# Altium schematic sheet BearPi_Release A0201.SchDoc
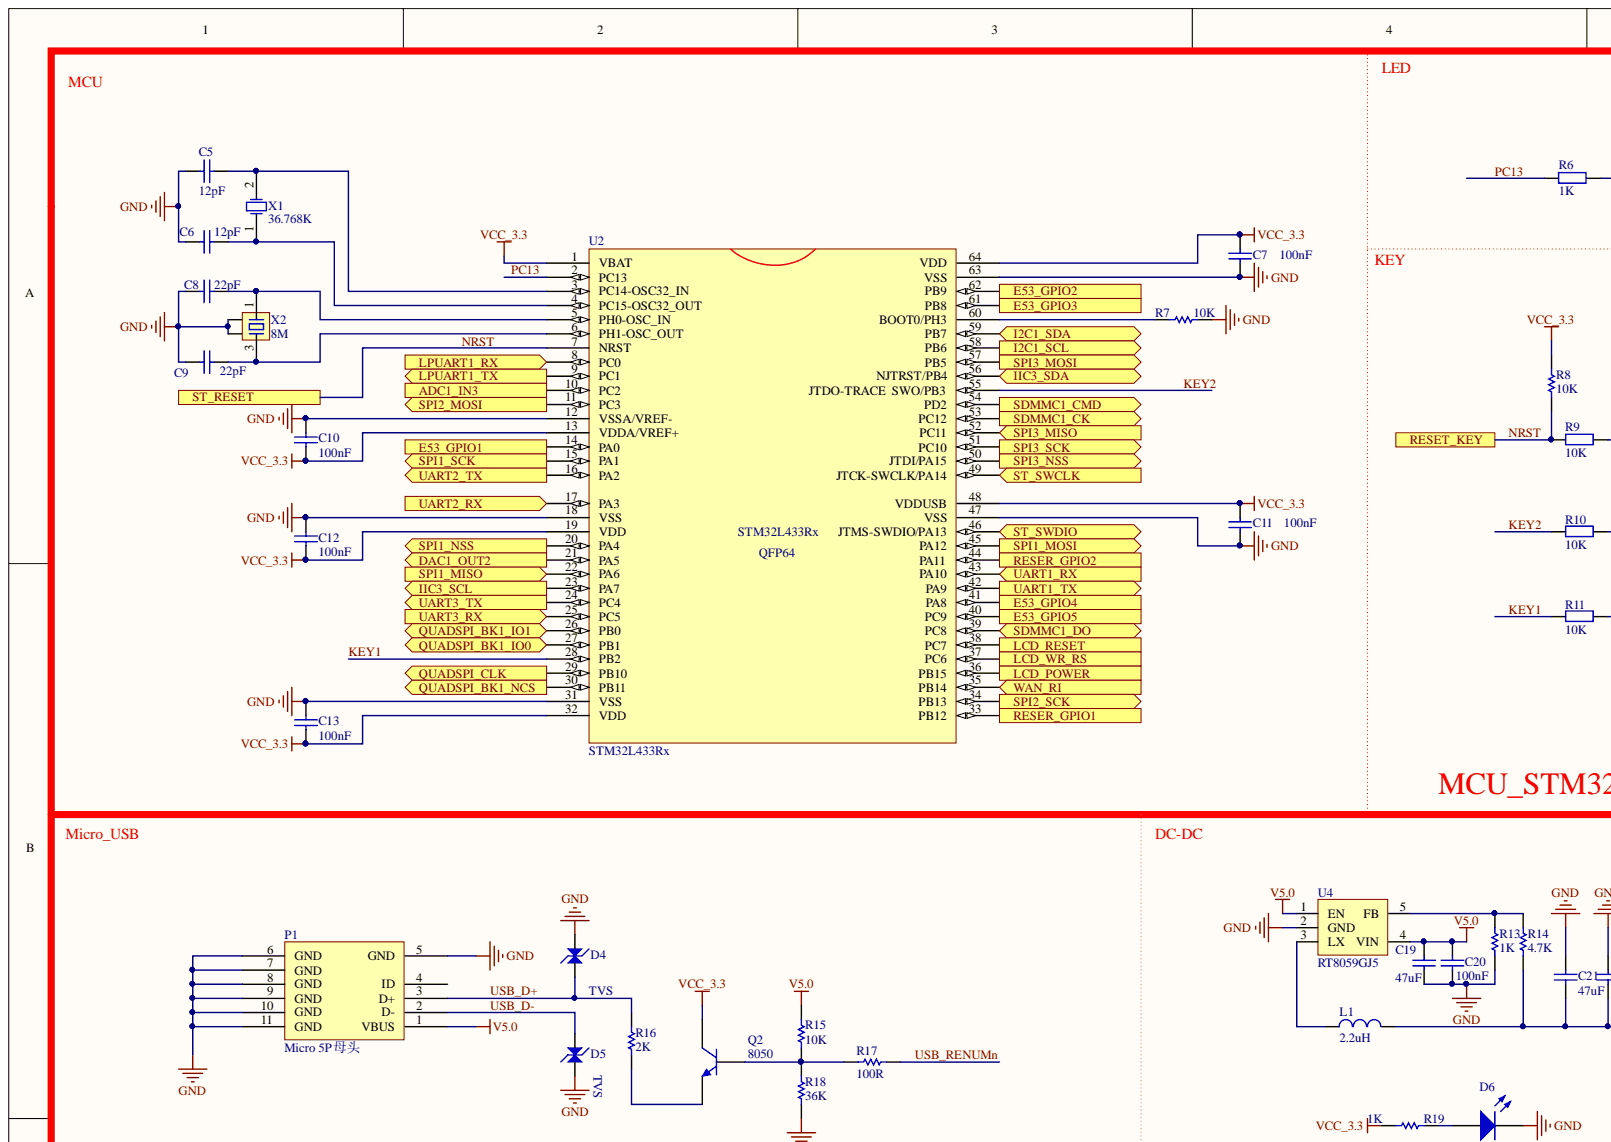
[diagram p0: BearPi_Release A0201.SchDoc, top left]
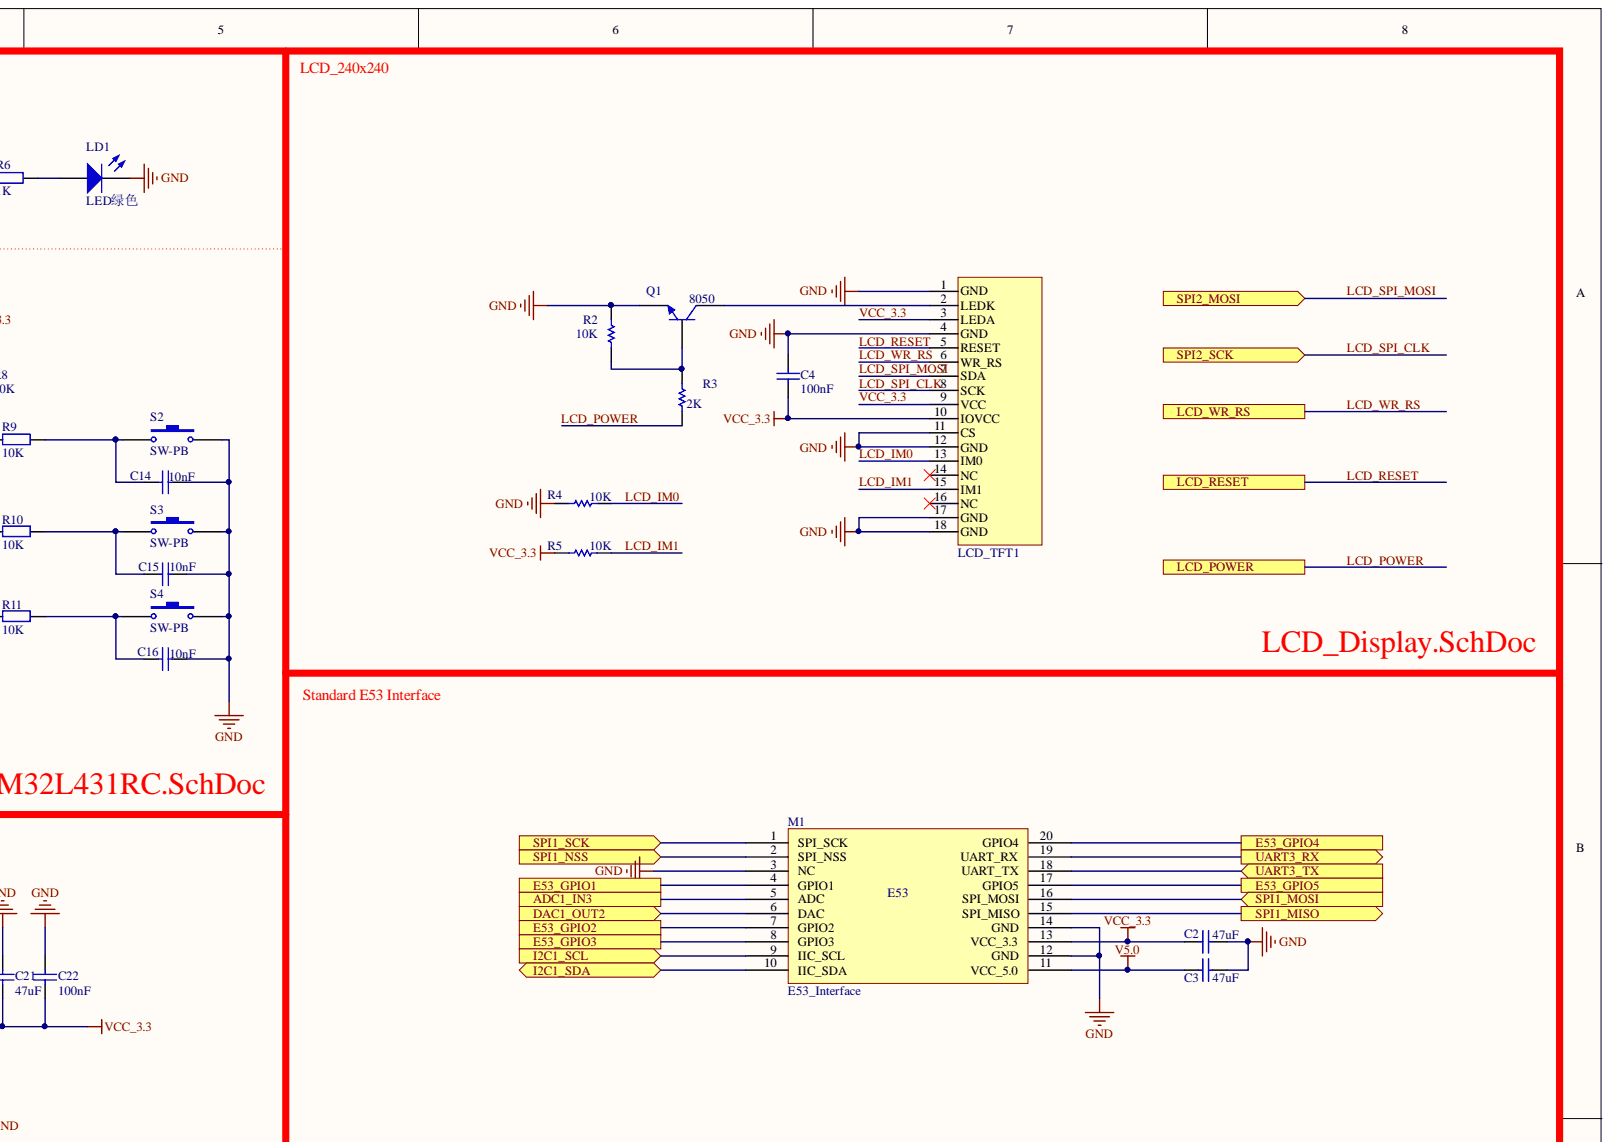
[diagram p1: BearPi_Release A0201.SchDoc, top right]
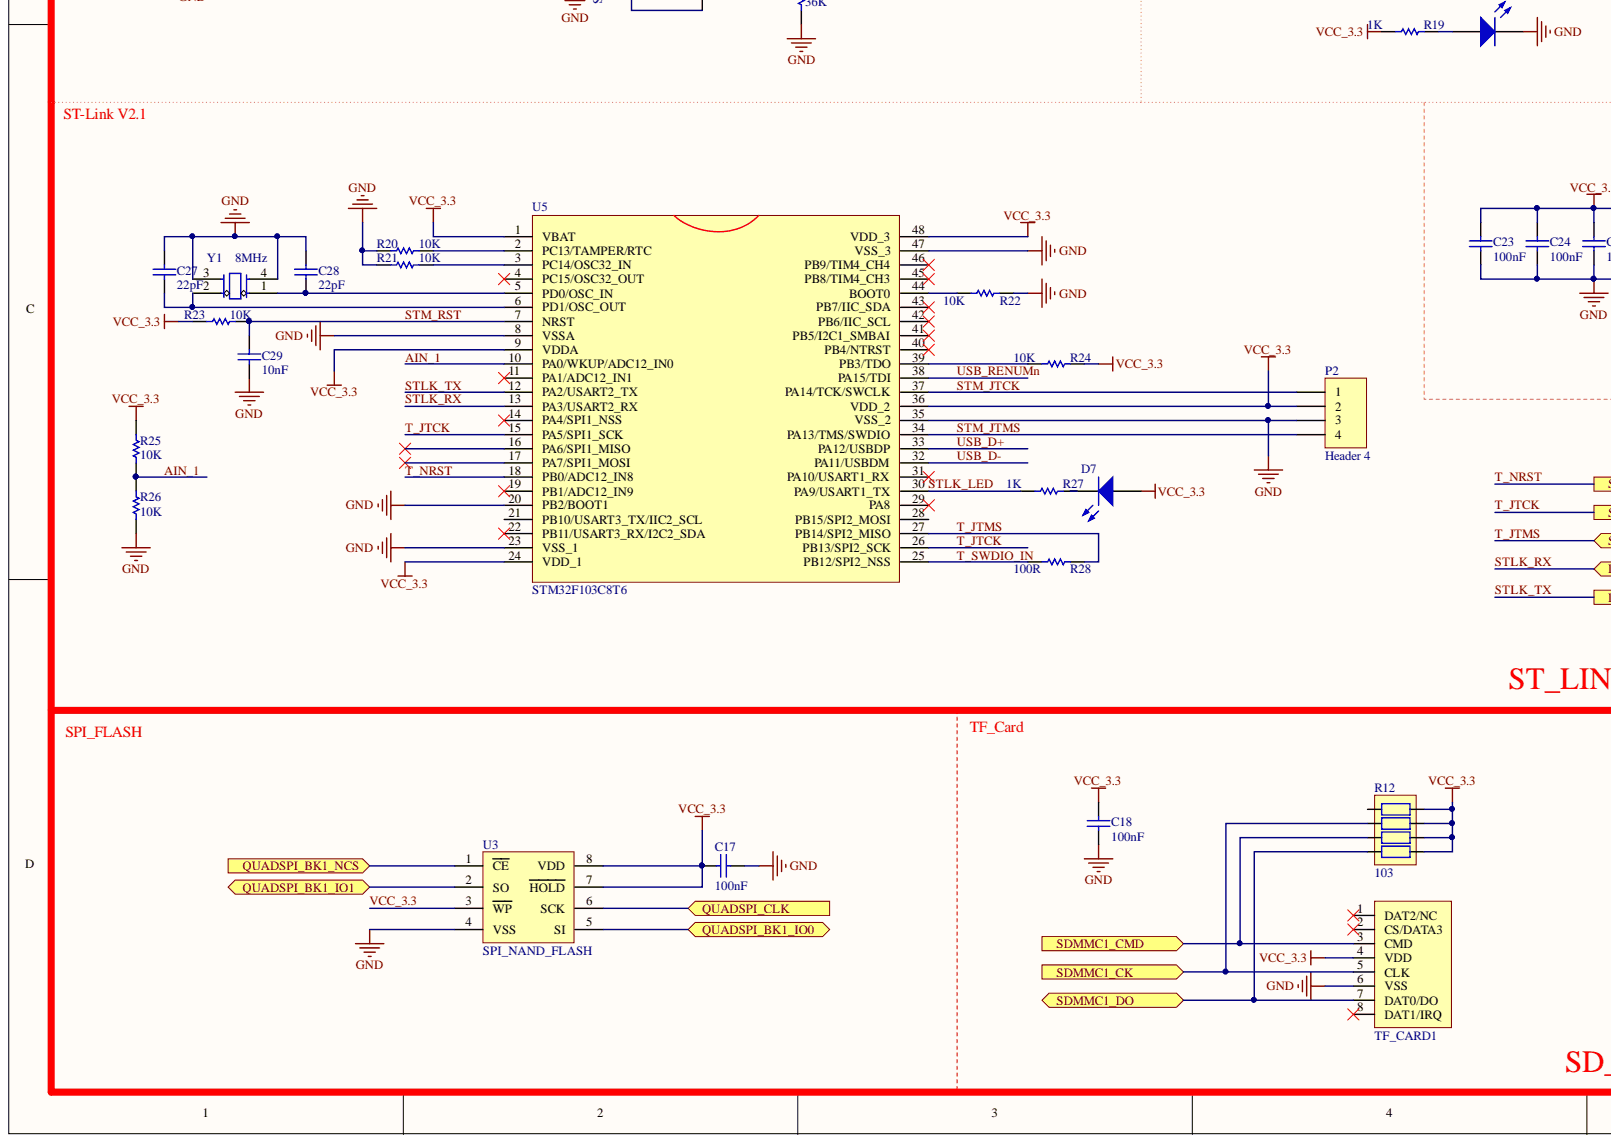
[diagram p2: BearPi_Release A0201.SchDoc, bottom left]
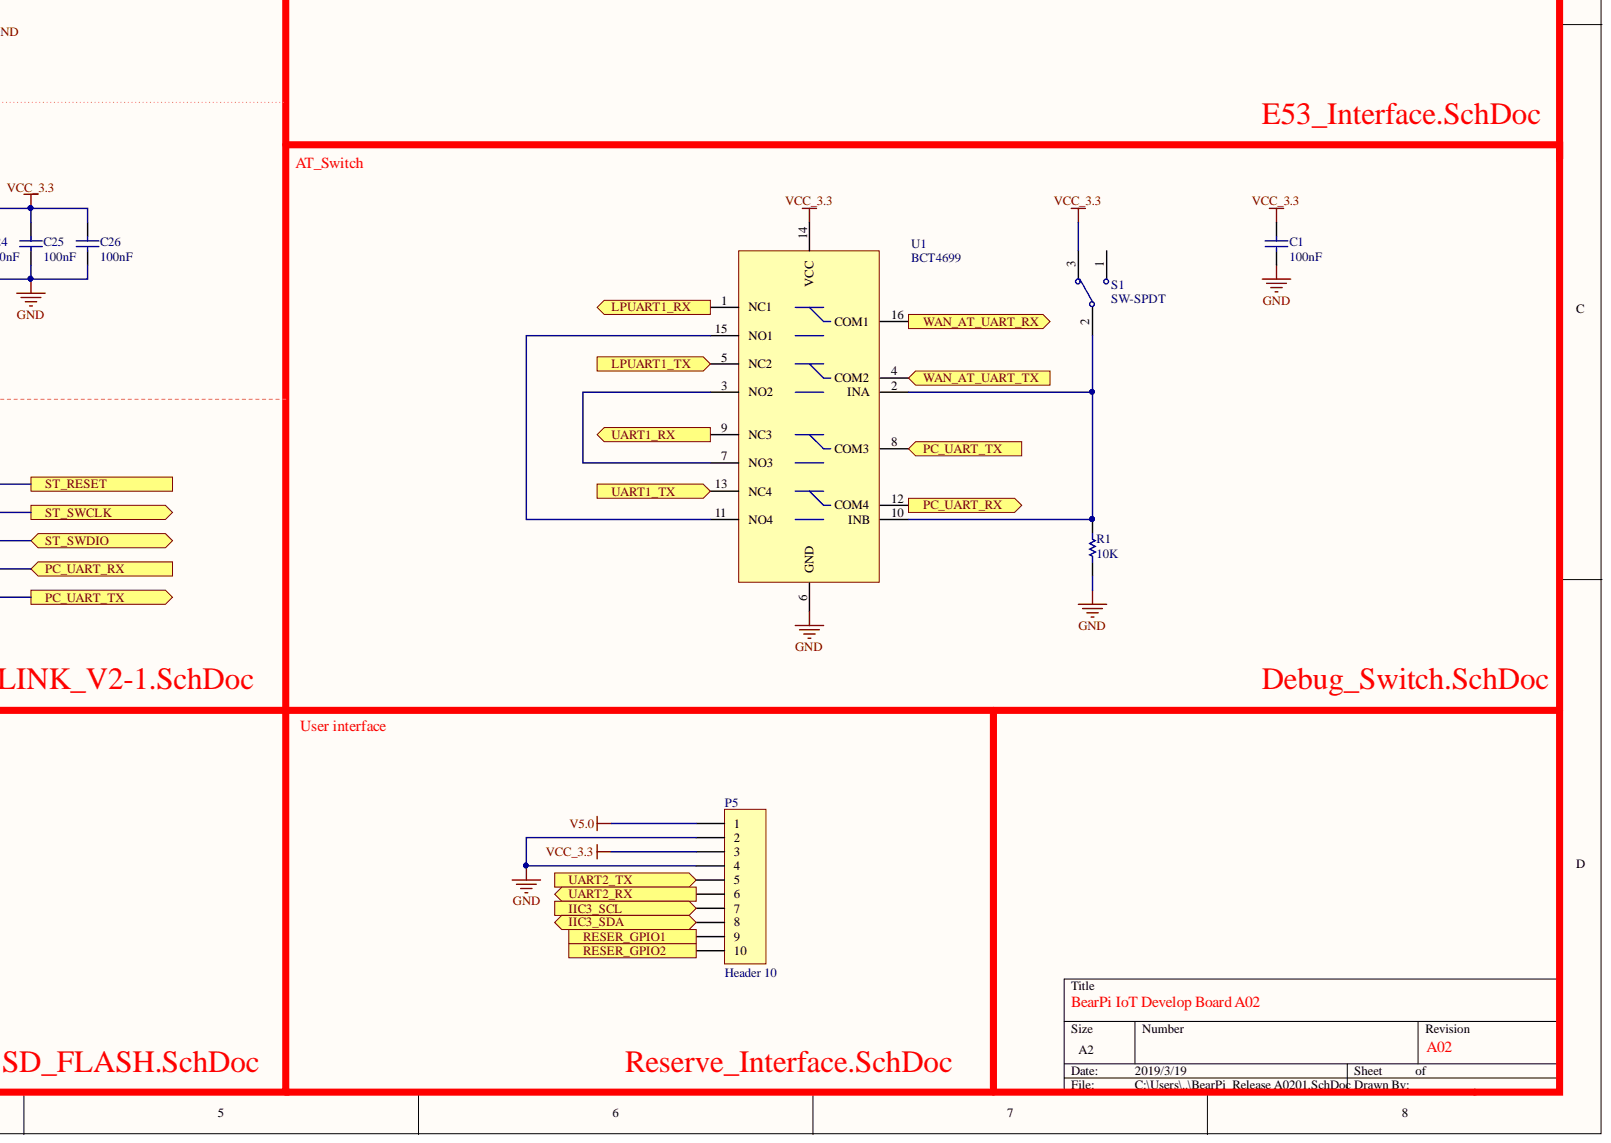
[diagram p3: BearPi_Release A0201.SchDoc, bottom right]

## Parts
C1  100nF  [C0603]
C2  47uF  [LC-0805_C]
C3  47uF  [LC-0805_C]
C4  100nF  [C0603]
C5  12pF  [C0603]
C6  12pF  [C0603]
C7  100nF  [C0603]
C8  22pF  [C0603]
C9  22pF  [C0603]
C10  100nF  [C0603]
C11  100nF  [C0603]
C12  100nF  [C0603]
C13  100nF  [C0603]
C14  10nF  [C0603]
C15  10nF  [C0603]
C16  10nF  [C0603]
C17  100nF  [C0603]
C18  100nF  [C0603]
C19  47uF  [LC-0805_C]
C20  100nF  [C0603]
C21  47uF  [LC-0805_C]
C22  100nF  [C0603]
C23  100nF  [C0603]
C24  100nF  [C0603]
C25  100nF  [C0603]
C26  100nF  [C0603]
C27  22pF  [C0603]
C28  22pF  [C0603]
C29  10nF  [C0603]
D4  TVS  [TVS_0603]
D5  TVS  [TVS_0603]
D6  LED  [LC-0603_LED_S2]  (value not drawn)
D7  LED  [LC-0603_LED_S2]  (value not drawn)
L1  2.2uH  [NR2520]
LCD_TFT1  LCD-TFT-H13TS38A  [TFT-H13TS43B]  (value not drawn)
LD1  LED绿色  [LED_0603_R]
M1  E53_Interface  [EXT_M_BearPi]
P1  Micro 5P母头  [USB_Micro]
P2  Header 4  [HDR1.27-LI-4P]
P5  Header 10  [HDR1X10]
Q1  8050  [SOT23_N - 123]
Q2  8050  [SOT23_N - 123]
R1  10K  [R0603]
R2  10K  [R0603]
R3  2K  [R0603]
R4  10K  [R0603]
R5  10K  [R0603]
R6  1K  [R0603]
R7  10K  [R0603]
R8  10K  [R0603]
R9  10K  [R0603]
R10  10K  [R0603]
R11  10K  [R0603]
R12  103  [RCA-8P4R-0603]
R13  1K  [R0603]
R14  4.7K  value 1K  [R0603]
R15  10K  [R0603]
R16  2K  [R0603]
R17  100R  [R0603]
R18  36K  [R0603]
R19  1K  [R0603]
R20  10K  [R0603]
R21  10K  [R0603]
R22  10K  [R0603]
R23  10K  [R0603]
R24  10K  [R0603]
R25  10K  [R0603]
R26  10K  [R0603]
R27  1K  [R0603]
R28  100R  [R0603]
S1  SW-SPDT  [MSK-12C01-07]
S2  SW-PB  [SMD_6X6X4.3]
S3  SW-PB  [SMD_6X6X4.3]
S4  SW-PB  [SMD_6X6X4.3]
TF_CARD1  SD-MICRO-TF  [SD-CARD-TF]  (value not drawn)
U1  BCT4699  [QFN16]
U2  STM32L433Rx  [LQFP64]
U3  SPI_NAND_FLASH  [SOP-8-V]
U4  RT8059GJ5  [RT8059GJ5]
U5  STM32F103C8T6  [LQFP48X]
X1  36.768K  [3215]
X2  8M  [OSC 3225-4P]
Y1  8MHz  [XTAL_3225]

## Ports
ADC1_IN3, DAC1_OUT2, E53_GPIO1, E53_GPIO2, E53_GPIO3, E53_GPIO4, E53_GPIO5, I2C1_SCL, I2C1_SDA, IIC3_SCL, IIC3_SDA, LCD_POWER, LCD_RESET, LCD_WR_RS, LPUART1_RX, LPUART1_TX, PC_UART_RX, PC_UART_TX, QUADSPI_BK1_IO0, QUADSPI_BK1_IO1, QUADSPI_BK1_NCS, QUADSPI_CLK, RESER_GPIO1, RESER_GPIO2, RESET_KEY, SDMMC1_CK, SDMMC1_CMD, SDMMC1_DO, SPI1_MISO, SPI1_MOSI, SPI1_NSS, SPI1_SCK, SPI2_MOSI, SPI2_SCK, SPI3_MISO, SPI3_MOSI, SPI3_NSS, SPI3_SCK, ST_RESET, ST_SWCLK, ST_SWDIO, UART1_RX, UART1_TX, UART2_RX, UART2_TX, UART3_RX, UART3_TX, WAN_AT_UART_RX, WAN_AT_UART_TX, WAN_RI

## Nets
GND: C1.1 C2.2 C3.2 C4.2 C5.1 C6.1 C7.2 C8.1 C9.1 C10.1 C11.1 C12.1 C13.1 C14.2 C15.2 C16.2 C17.2 C18.1 C19.1 C20.2 C21.2 C22.2 C23.1 C24.1 C25.1 C26.1 C27.1 C28.1 C29.1 D4.1 D5.2 D6.2 LCD_TFT1.1(GND) LCD_TFT1.4(GND) LCD_TFT1.11(CS) LCD_TFT1.12(GND) LCD_TFT1.17(GND) LCD_TFT1.18(GND) LD1.K M1.3(NC) M1.12(GND) M1.14(GND) P1.5(GND) P1.6(GND) P1.7(GND) P1.8(GND) P1.9(GND) P1.10(GND) P1.11(GND) P2.3 P5.2 P5.4 Q1.2 R1.1 R2.2 R4.1 R7.2 R13.1 R18.1 R20.1 R21.1 R22.1 R26.1 S2.2 S3.2 S4.2 TF_CARD1.6(VSS) U1.6(GND) U2.12(VSSA/VREF-) U2.18(VSS) U2.31(VSS) U2.47(VSS) U2.63(VSS) U3.4(VSS) U4.2(GND) U5.8(VSSA) U5.20(PB2/BOOT1) U5.23(VSS_1) U5.35(VSS_2) U5.47(VSS_3) X2.2 X2.4 Y1.3 Y1.4
V5.0: C3.1 C19.2 C20.1 M1.11(VCC_5.0) P1.1(VBUS) P5.1 R15.2 U4.1(EN) U4.4(VIN)
ADC1_IN3: M1.5(ADC) U2.10(PC2) ; port ADC1_IN3
AIN_1: R25.1 R26.2 U5.10(PA0/WKUP/ADC12_IN0)
DAC1_OUT2: M1.6(DAC) U2.21(PA5) ; port DAC1_OUT2
E53_GPIO1: M1.4(GPIO1) U2.14(PA0) ; port E53_GPIO1
E53_GPIO2: M1.7(GPIO2) U2.62(PB9) ; port E53_GPIO2
E53_GPIO3: M1.8(GPIO3) U2.61(PB8) ; port E53_GPIO3
E53_GPIO4: M1.20(GPIO4) U2.41(PA8) ; port E53_GPIO4
E53_GPIO5: M1.17(GPIO5) U2.40(PC9) ; port E53_GPIO5
I2C1_SCL: M1.9(IIC_SCL) U2.58(PB6) ; port I2C1_SCL
I2C1_SDA: M1.10(IIC_SDA) U2.59(PB7) ; port I2C1_SDA
IIC3_SCL: P5.7 U2.23(PA7) ; port IIC3_SCL
IIC3_SDA: P5.8 U2.56(NJTRST/PB4) ; port IIC3_SDA
KEY1: R11.1 U2.28(PB2)
KEY2: R10.1 U2.55(SWO/PB3)
LCD_IM0: LCD_TFT1.13(IM0) R4.2
LCD_IM1: LCD_TFT1.15(IM1) R5.2
LCD_POWER: R3.2 U2.36(PB15) ; port LCD_POWER
LCD_RESET: LCD_TFT1.5(RESET) U2.38(PC7) ; port LCD_RESET
LCD_SPI_CLK: LCD_TFT1.8(SCK) U2.34(PB13) ; port SPI2_SCK
LCD_SPI_MOSI: LCD_TFT1.7(SDA) U2.11(PC3) ; port SPI2_MOSI
LCD_WR_RS: LCD_TFT1.6(WR_RS) U2.37(PC6) ; port LCD_WR_RS
LPUART1_RX: U1.1(NC1) U2.8(PC0) ; port LPUART1_RX
LPUART1_TX: U1.5(NC2) U2.9(PC1) ; port LPUART1_TX
NRST: R8.1 R9.1 U2.7(NRST) U5.18(PB0/ADC12_IN8) ; port RESET_KEY, port ST_RESET
PC13: R6.1 U2.2(PC13)
QUADSPI_BK1_IO0: U2.27(PB1) U3.5(SI) ; port QUADSPI_BK1_IO0
QUADSPI_BK1_IO1: U2.26(PB0) U3.2(SO) ; port QUADSPI_BK1_IO1
QUADSPI_BK1_NCS: U2.30(PB11) U3.1(CE) ; port QUADSPI_BK1_NCS
QUADSPI_CLK: U2.29(PB10) U3.6(SCK) ; port QUADSPI_CLK
RESER_GPIO1: P5.9 U2.33(PB12) ; port RESER_GPIO1
RESER_GPIO2: P5.10 U2.44(PA11) ; port RESER_GPIO2
SDMMC1_CK: R12.2 TF_CARD1.5(CLK) U2.53(PC12) ; port SDMMC1_CK
SDMMC1_CMD: R12.3 TF_CARD1.3(CMD) U2.54(PD2) ; port SDMMC1_CMD
SDMMC1_DO: R12.4 TF_CARD1.7(DAT0/DO) U2.39(PC8) ; port SDMMC1_DO
SPI1_MISO: M1.15(SPI_MISO) U2.22(PA6) ; port SPI1_MISO
SPI1_MOSI: M1.16(SPI_MOSI) U2.45(PA12) ; port SPI1_MOSI
SPI1_NSS: M1.2(SPI_NSS) U2.20(PA4) ; port SPI1_NSS
SPI1_SCK: M1.1(SPI_SCK) U2.15(PA1) ; port SPI1_SCK
SPI3_MISO: U2.52(PC11) ; port SPI3_MISO
SPI3_MOSI: U2.57(PB5) ; port SPI3_MOSI
SPI3_NSS: U2.50(JTDI/PA15) ; port SPI3_NSS
SPI3_SCK: U2.51(PC10) ; port SPI3_SCK
STLK_LED: R27.2 U5.30(PA9/USART1_TX)
STLK_RX: U1.12(COM4) U5.13(PA3/USART2_RX) ; port PC_UART_RX
STLK_TX: U1.8(COM3) U5.12(PA2/USART2_TX) ; port PC_UART_TX
STM_JTCK: P2.1 U5.37(PA14/TCK/SWCLK)
STM_JTMS: P2.4 U5.34(PA13/TMS/SWDIO)
STM_RST: C29.2 R23.1 U5.7(NRST)
T_JTCK: U2.49(JTCK-SWCLK/PA14) U5.15(PA5/SPI1_SCK) U5.26(PB13/SPI2_SCK) ; port ST_SWCLK
T_JTMS: R28.1 U2.46(JTMS-SWDIO/PA13) U5.27(PB14/SPI2_MISO) ; port ST_SWDIO
T_SWDIO_IN: R28.2 U5.25(PB12/SPI2_NSS)
UART1_RX: U1.9(NC3) U2.43(PA10) ; port UART1_RX
UART1_TX: U1.13(NC4) U2.42(PA9) ; port UART1_TX
UART2_RX: P5.6 U2.17(PA3) ; port UART2_RX
UART2_TX: P5.5 U2.16(PA2) ; port UART2_TX
UART3_RX: M1.19(UART_RX) U2.25(PC5) ; port UART3_RX
UART3_TX: M1.18(UART_TX) U2.24(PC4) ; port UART3_TX
USB_D+: D4.2 P1.3(D+) R16.2 U5.33(PA12/USBDP)
USB_D-: D5.1 P1.2(D-) U5.32(PA11/USBDM)
USB_RENUMn: R17.1 U5.38(PA15/TDI)
VCC_3.3: C1.2 C2.1 C4.1 C7.1 C10.2 C11.2 C12.2 C13.2 C17.1 C18.2 C21.1 C22.1 C23.2 C24.2 C25.2 C26.2 D7.1 L1.2 LCD_TFT1.3(LEDA) LCD_TFT1.9(VCC) LCD_TFT1.10(IOVCC) M1.13(VCC_3.3) P2.2 P5.3 Q2.3 R5.1 R8.2 R12.5 R12.6 R12.7 R12.8 R14.1 R19.2 R23.2 R24.1 R25.2 S1.3 TF_CARD1.4(VDD) U1.14(VCC) U2.1(VBAT) U2.13(VDDA/VREF+) U2.19(VDD) U2.32(VDD) U2.48(VDDUSB) U2.64(VDD) U3.3(WP) U3.7(HOLD) U3.8(VDD) U5.1(VBAT) U5.9(VDDA) U5.24(VDD_1) U5.36(VDD_2) U5.48(VDD_3)
WAN_AT_UART_RX: U1.16 ; port WAN_AT_UART_RX
WAN_AT_UART_TX: U1.4(COM2) ; port WAN_AT_UART_TX
WAN_RI: U2.35(PB14) ; port WAN_RI
NetC5_2: C5.2 U2.3(PC14-OSC32_IN) X1.2
NetC6_2: C6.2 U2.4(PC15-OSC32_OUT) X1.1
NetC8_2: C8.2 U2.5(PH0-OSC_IN) X2.1
NetC9_2: C9.2 U2.6(PH1-OSC_OUT) X2.3
NetC14_1: C14.1 R9.2 S2.1
NetC15_1: C15.1 R10.2 S3.1
NetC16_1: C16.1 R11.2 S4.1
NetC27_2: C27.2 U5.6(PD1/OSC_OUT) Y1.2
NetC28_2: C28.2 U5.5(PD0/OSC_IN) Y1.1
NetD6_1: D6.1 R19.1
NetD7_2: D7.2 R27.1
NetL1_1: L1.1 U4.3(LX)
NetLCD_TFT1_2: LCD_TFT1.2(LEDK) Q1.3
NetLD1_A: LD1.A R6.2
NetQ1_1: Q1.1 R2.1 R3.1
NetQ2_1: Q2.1 R15.1 R17.2 R18.2
NetQ2_2: Q2.2 R16.1
NetR1_2: R1.2 S1.2 U1.2(INA) U1.10(INB)
NetR7_1: R7.1 U2.60(BOOT0/PH3)
NetR13_2: R13.2 R14.2 U4.5(FB)
NetR20_2: R20.2 U5.2(PC13/TAMPER/RTC)
NetR21_2: R21.2 U5.3(PC14/OSC32_IN)
NetR22_2: R22.2 U5.44(BOOT0)
NetR24_2: R24.2 U5.39(PB3/TDO)
NetU1_3: U1.3(NO2) U1.7(NO3)
NetU1_11: U1.11(NO4) U1.15(NO1)

Not connected: LCD_TFT1 14(NC) 16(NC); P1 4(ID); R12 1; S1 1; TF_CARD1 1(DAT2/NC) 2(CS/DATA3) 8(DAT1/IRQ); U5 4(PC15/OSC32_OUT) 11(PA1/ADC12_IN1) 14(PA4/SPI1_NSS) 16(PA6/SPI1_MISO) 17(PA7/SPI1_MOSI) 19(PB1/ADC12_IN9) 21(PB10/USART3_TX/IIC2_SCL) 22(PB11/USART3_RX/I2C2_SDA) 28(PB15/SPI2_MOSI) 29(PA8) 31(PA10/USART1_RX) 40(PB4/NTRST) 41(PB5/I2C1_SMBAI) 42(PB6/IIC_SCL) 43(PB7/IIC_SDA) 45(PB8/TIM4_CH3) 46(PB9/TIM4_CH4)

## Library data of the parts
C1-C29 = CAP: Capacitor; Component Kind: Standard
  C1-C7 C10-C29: Library Name: Devices.SchLib
  C8 C9: Library Name: Devices.IntLib
D6 D7 = LED1: Typical RED GaAs LED; Component Kind: Standard; LatestRevisionDate: 08-Jul-2005; LatestRevisionNote: Mech Layer 1 removed.; Library Name: Devices.IntLib; PackageDescription: LED; 2 Leads; PackageReference: LED-1; Published: 8-Jun-2000; Simulation: LED1; Publisher: Altium Limited
L1 = Inductor: Code_IEC: 1005; Code_JEITA: 0402; Component Kind: Standard; Description: Inductor; LatestRevisionDate: 29-May-2009; LatestRevisionNote: IPC-7351 Footprint Added.; Library Name: Devices.SchLib; PackageDescription: Chip Inductor; PackageReference: 0402-A; Published: 8-Jun-2000; Signal Integrity: Inductor; Simulation: INDUCTOR; Publisher: Altium Limited
LCD_TFT1 = LCD-TFT-H13TS38A: LCD,TFT,1.3'240*240,禹龙; Component Kind: Standard; Library Name: Custom.IntLib
LD1 = LED: Component Kind: Standard; Description: LED; Library Name: Basic Firmware Library.IntLib
M1 = E53: Component Kind: Standard; Library Name: BearPi.SCHLIB
P1 = USB_Micro: Component Kind: Standard; Library Name: Custom.IntLib
P2 = Header 4: Header, 4-Pin; Component Kind: Standard; LatestRevisionDate: 17-Jul-2002; LatestRevisionNote: Re-released for DXP Platform.; Library Name: Connectors.SchLib; Signal Integrity: Connector; Publisher: Altium Limited
P5 = Header 10: Header, 10-Pin; Component Kind: Standard; LatestRevisionDate: 17-Jul-2002; LatestRevisionNote: Re-released for DXP Platform.; Library Name: Connectors.IntLib; Signal Integrity: Connector; Publisher: Altium Limited
Q1 Q2 = NPN: NPN Bipolar Transistor; Code_JEDEC: TO-226-AA; Component Kind: Standard; LatestRevisionDate: 17-Jul-2002; LatestRevisionNote: Re-released for DXP Platform.; Library Name: Devices.IntLib; PackageDescription: TO, Flat Index; 3 In-Line, Axial Leads; Body Dia. 4.8mm; Leads 0.55 x 0.51 mm (max); PackageReference: TO-226-AA; Published: 8-Jun-2000; Signal Integrity: NPN; Simulation: NPN; Publisher: Altium Limited
R1-R5 R7 R8 R15-R18 R20-R26 R28 = Res1: Resistor; Value: 1K; Component Kind: Standard; LatestRevisionDate: 17-Jul-2002; LatestRevisionNote: Re-released for DXP Platform.; Library Name: Devices.IntLib; PackageDescription: Axial Device, Thru-Hole; 2 Leads; 0.3 in Pin Spacing; PackageReference: AXIAL-0.3; Published: 8-Jun-2000; Signal Integrity: Res; Simulation: RESISTOR; Publisher: Altium Limited
R6 = Res: Resistor; Component Kind: Standard; LatestRevisionDate: 17-Jul-2002; LatestRevisionNote: Re-released for DXP Platform.; PackageDescription: Axial Device, Thru-Hole; 2 Leads; 0.3 in Pin Spacing; PackageReference: AXIAL-0.3; Published: 8-Jun-2000; Value: 1K; Library Name: Basic Firmware Library.IntLib; Publisher: Altium Limited
R9-R11 = Res: Resistor; Value: 1K; Component Kind: Standard; LatestRevisionDate: 17-Jul-2002; LatestRevisionNote: Re-released for DXP Platform.; PackageDescription: Axial Device, Thru-Hole; 2 Leads; 0.3 in Pin Spacing; PackageReference: AXIAL-0.3; Published: 8-Jun-2000; Publisher: Altium Limited
  R9 R10: Library Name: EVB_L1.SCHLIB
  R11: Library Name: Basic Firmware Library.IntLib
R12 = R-8P4R: 贴片网络排阻; 版权归属: 源创客; BOM名称: 贴片网络排阻; Component Kind: Standard; Library Name: Devices.IntLib; 学习资源: www.ooocke.com
R13 R14 R19 R27 = Res1: Resistor; Component Kind: Standard; LatestRevisionDate: 17-Jul-2002; LatestRevisionNote: Re-released for DXP Platform.; Library Name: Devices.IntLib; PackageDescription: Axial Device, Thru-Hole; 2 Leads; 0.3 in Pin Spacing; PackageReference: AXIAL-0.3; Published: 8-Jun-2000; Signal Integrity: Res; Simulation: RESISTOR; Publisher: Altium Limited
  R13 R19 R27: Value: 1K
S1 = SW-SPDT: SPDT Subminiature Toggle Switch, Right Angle Mounting, Vertical Actuation; Component Kind: Standard; ComponentLink1Description: Manufacturer Link; ComponentLink1URL: http://www.apem.com; DatasheetVersion: 05-Dec-2000; LatestRevisionDate: 17-Dec-2003; LatestRevisionNote: Schematic revised, PCB Footprint replaced.; Library Name: Devices.IntLib; PackageDescription: Switch; 4 Leads; PackageReference: TL36WW15050; Published: 24-Mar-1999; Publisher: Altium Limited
S2-S4 = SW-PB: Switch; Component Kind: Standard; LatestRevisionDate: 17-Jul-2002; LatestRevisionNote: Re-released for DXP Platform.; Library Name: Devices.IntLib; PackageDescription: Switch; 2 Leads; PackageReference: SPST-2; Published: 24-Mar-1999; Publisher: Altium Limited
TF_CARD1 = SD-MICRO-TF: SD,MICRO,TF; Component Kind: Standard; Library Name: Custom.SchLib
U2 = STM32L433Rx: 超低功耗高性能ARM Cortex-M4 32-bit MCU+FPU 80MHz 100DMIPS; Component Kind: Standard; Library Name: IC.IntLib
X1 = XTAL_FC135: XTAL; Component Kind: Standard; Library Name: Devices.IntLib
X2 = XTAL-4P: 4脚无源晶振; 版权归属: 源创客; BOM名称: 4脚无源晶振; Component Kind: Standard; Library Name: Devices.IntLib; 学习资源: www.ooocke.com
Y1 = XTAL_4: XTAL; Component Kind: Standard; Library Name: Devices.IntLib
D4 D5 = TVS: Component Kind: Standard; Library Name: Devices.SchLib
U1 = BCT4699: Component Kind: Standard; Library Name: IC.SchLib
U3 = SPI_NAND_FLASH: Component Kind: Standard; Library Name: IC.IntLib
U4 = RT8059GJ5: Component Kind: Standard; Library Name: Basic Firmware Library.IntLib
U5 = STM32F103C8T6: Component Kind: Standard; Library Name: IC.SchLib
